# Altium schematic sheet SPU_circuit.SchDoc
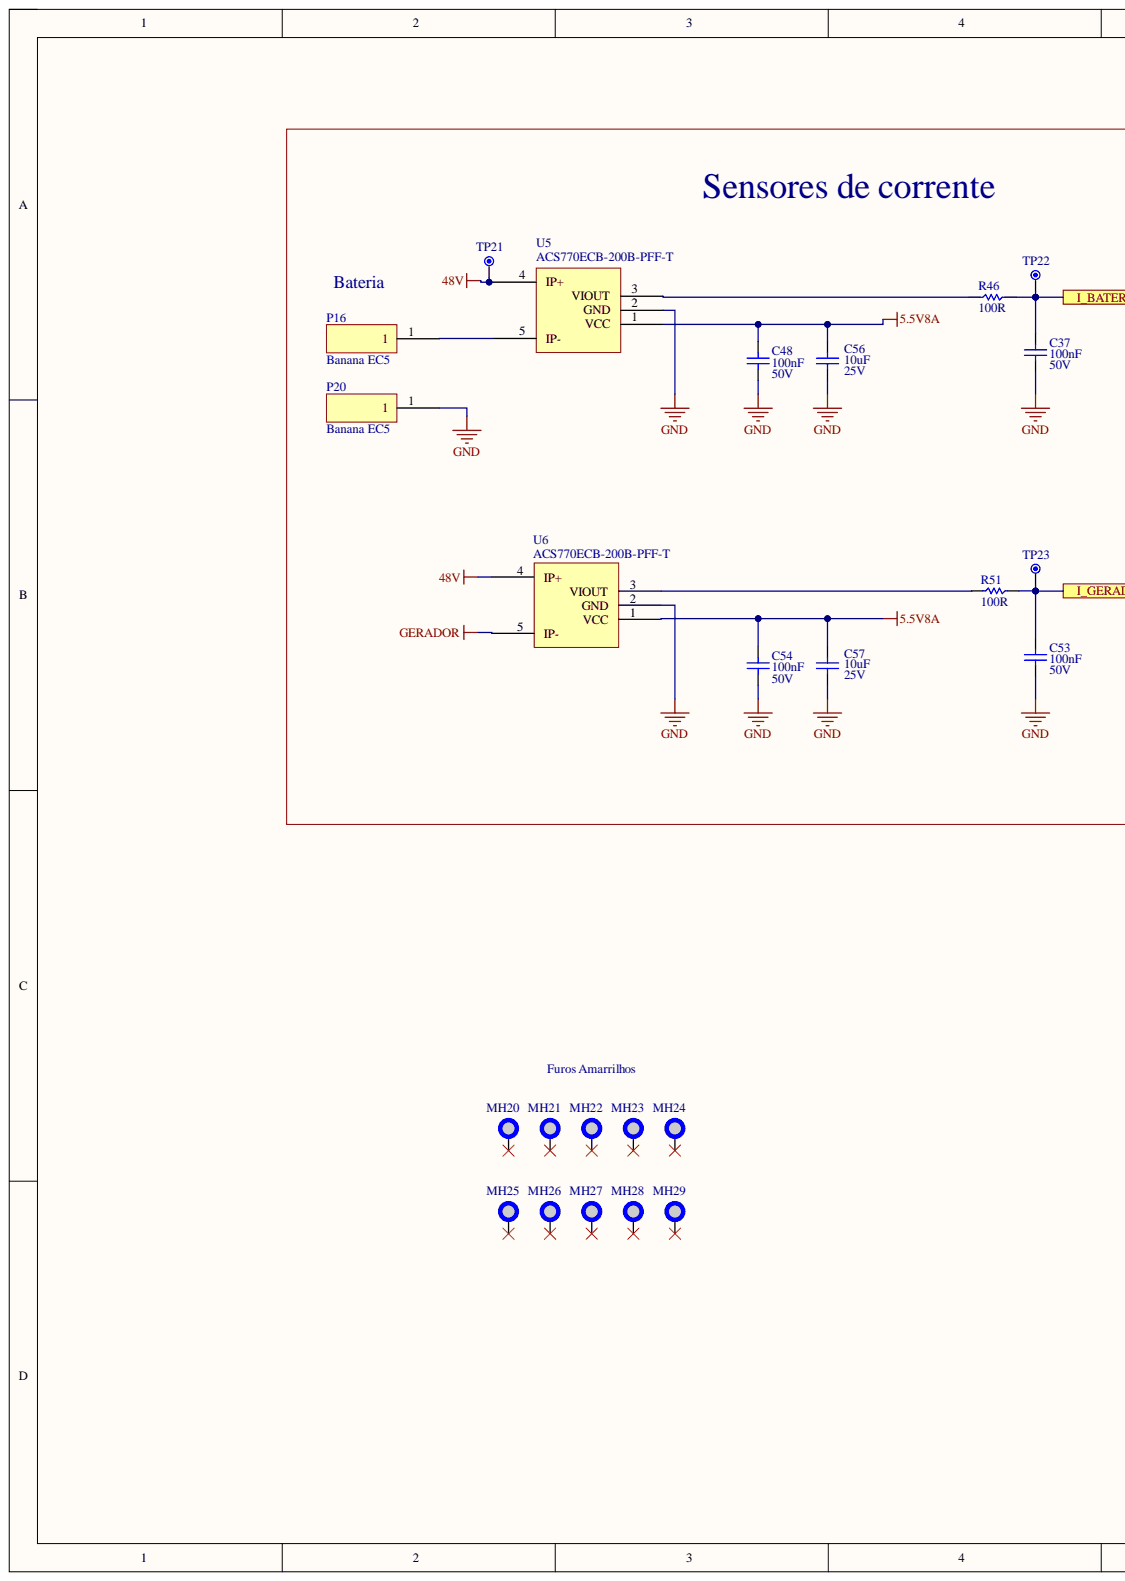
[diagram p0: SPU_circuit.SchDoc, left]
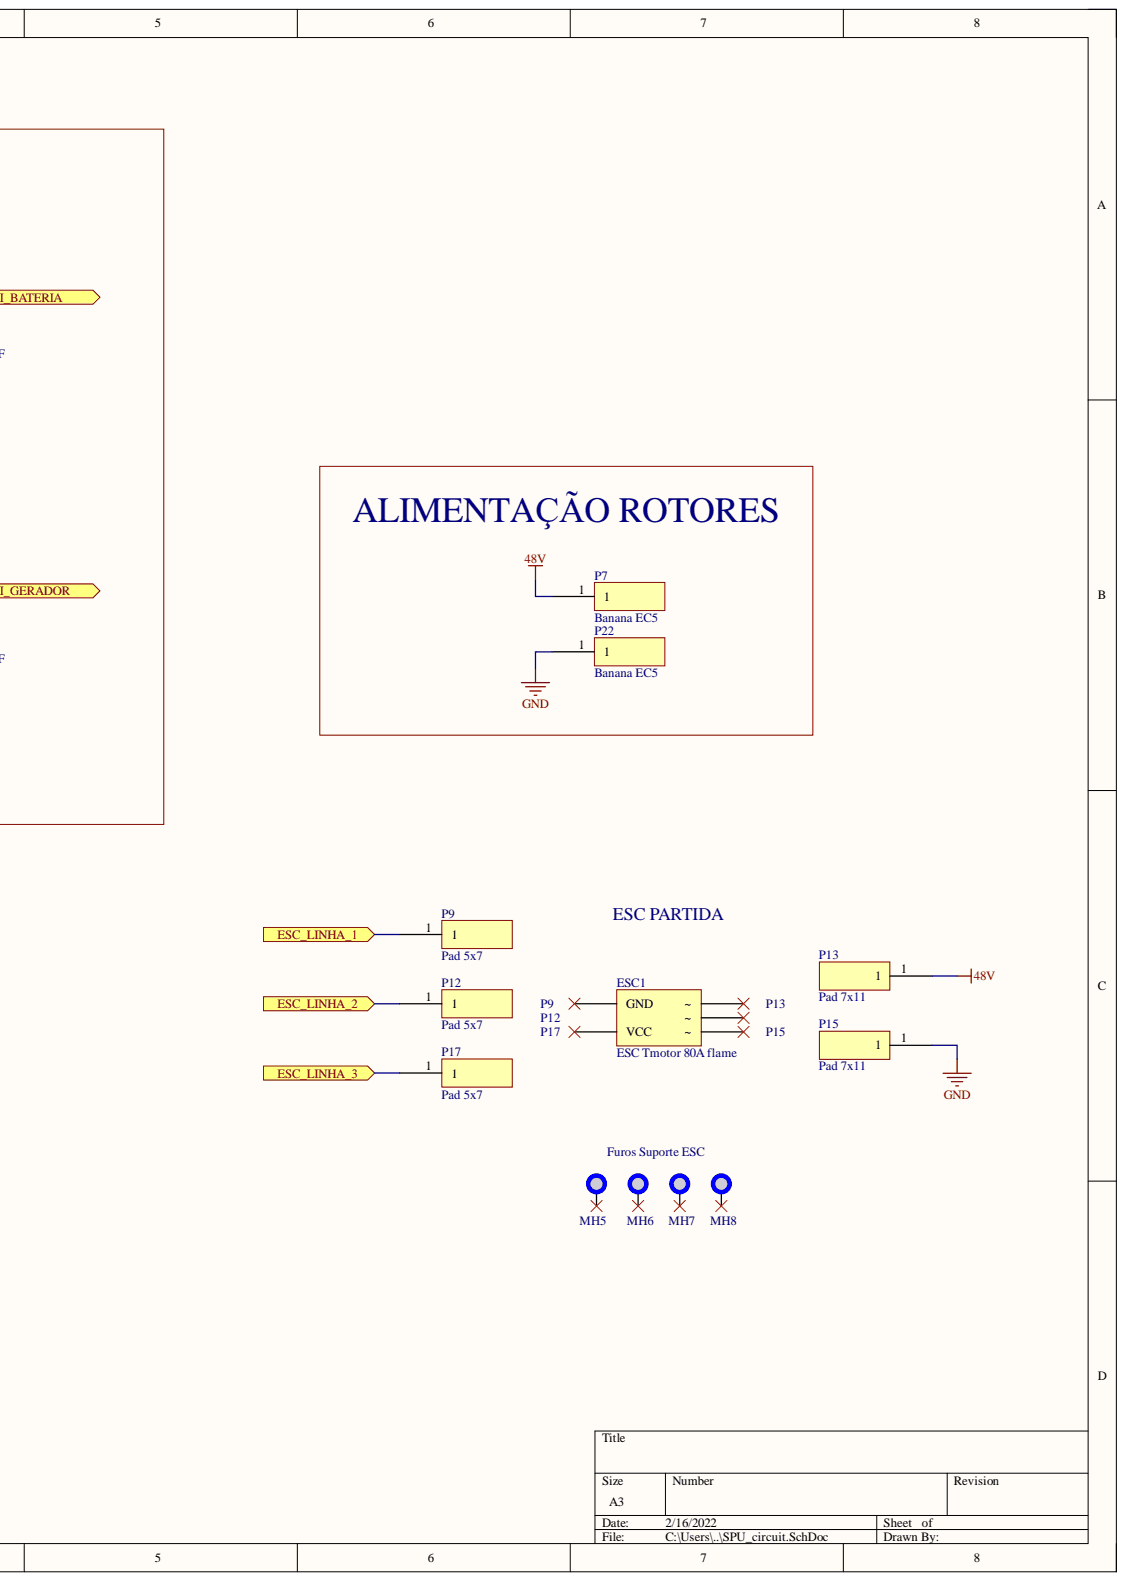
[diagram p1: SPU_circuit.SchDoc, right]

## Parts
C37  Capacitance: 100nF  Voltage: 50V  [SMD_0603]  (hidden comment: CL10B104MB8NNNC)
C48  Capacitance: 100nF  Voltage: 50V  [SMD_0603]  (hidden comment: CL10B104MB8NNNC)
C53  Capacitance: 100nF  Voltage: 50V  [SMD_0603]  (hidden comment: CL10B104MB8NNNC)
C54  Capacitance: 100nF  Voltage: 50V  [SMD_0603]  (hidden comment: CL10B104MB8NNNC)
C56  Capacitance: 10uF  Voltage: 25V  [1206]  (hidden comment: C3216X7R1E106K160AB)
C57  Capacitance: 10uF  Voltage: 25V  [1206]  (hidden comment: C3216X7R1E106K160AB)
ESC1  ESC Tmotor 80A flame  [ESC Tmotor 80Aflame - Unico]
MH5  TR-MOUNTING_HOLE-M2  [MOUNTING_HOLE-M3]  (value not drawn)
MH6  TR-MOUNTING_HOLE-M2  [MOUNTING_HOLE-M3]  (value not drawn)
MH7  TR-MOUNTING_HOLE-M2  [MOUNTING_HOLE-M3]  (value not drawn)
MH8  TR-MOUNTING_HOLE-M2  [MOUNTING_HOLE-M3]  (value not drawn)
MH20  TR-MOUNTING_HOLE-AMRARRILHA  [MOUNTING_HOLE-AMARRILHA]  (value not drawn)
MH21  TR-MOUNTING_HOLE-AMRARRILHA  [MOUNTING_HOLE-AMARRILHA]  (value not drawn)
MH22  TR-MOUNTING_HOLE-AMRARRILHA  [MOUNTING_HOLE-AMARRILHA]  (value not drawn)
MH23  TR-MOUNTING_HOLE-AMRARRILHA  [MOUNTING_HOLE-AMARRILHA]  (value not drawn)
MH24  TR-MOUNTING_HOLE-AMRARRILHA  [MOUNTING_HOLE-AMARRILHA]  (value not drawn)
MH25  TR-MOUNTING_HOLE-AMRARRILHA  [MOUNTING_HOLE-AMARRILHA]  (value not drawn)
MH26  TR-MOUNTING_HOLE-AMRARRILHA  [MOUNTING_HOLE-AMARRILHA]  (value not drawn)
MH27  TR-MOUNTING_HOLE-AMRARRILHA  [MOUNTING_HOLE-AMARRILHA]  (value not drawn)
MH28  TR-MOUNTING_HOLE-AMRARRILHA  [MOUNTING_HOLE-AMARRILHA]  (value not drawn)
MH29  TR-MOUNTING_HOLE-AMRARRILHA  [MOUNTING_HOLE-AMARRILHA]  (value not drawn)
P7  Banana EC5  [AWG8-MPU-VERTICAL]
P9  Pad 5x7  [FURO_7x5]
P12  Pad 5x7  [FURO_7x5]
P13  Pad 7x11  [FURO_11x7]
P15  Pad 7x11  [FURO_11x7]
P16  Banana EC5  [AWG8-MPU-VERTICAL]
P17  Pad 5x7  [FURO_7x5]
P20  Banana EC5  [AWG8-MPU-VERTICAL]
P22  Banana EC5  [AWG8-MPU-VERTICAL]
R46  Resistance: 100R  [SMD_0603]  (hidden comment: R-100R-0603)
R51  Resistance: 100R  [SMD_0603]  (hidden comment: R-100R-0603)
TP21  TP-1MM  [TESTPOINT-1MM]  (value not drawn)  (no BOM)
TP22  TP-1MM  [TESTPOINT-1MM]  (value not drawn)  (no BOM)
TP23  TP-1MM  [TESTPOINT-1MM]  (value not drawn)  (no BOM)
U5  ACS770ECB-200B-PFF-T  [CB-PFF-5_0]
U6  ACS770ECB-200B-PFF-T  [CB-PFF-5_0]

## Ports
ESC_LINHA_1, ESC_LINHA_2, ESC_LINHA_3, I_BATERIA, I_GERADOR

## Nets
5.5V8A: C48.2 C54.2 C56.2 C57.2 U5.1(VCC) U6.1(VCC)
48V: P7.1 P13.1 TP21.1 U5.4(IP+) U6.4(IP+)
GERADOR: U6.5(IP-)
GND: C37.1 C48.1 C53.1 C54.1 C56.1 C57.1 P15.1 P20.1 P22.1 U5.2(GND) U6.2(GND)
ESC_LINHA_1: P9.1 ; port ESC_LINHA_1
ESC_LINHA_2: P12.1 ; port ESC_LINHA_2
ESC_LINHA_3: P17.1 ; port ESC_LINHA_3
I_BATERIA: C37.2 R46.2 TP22.1 ; port I_BATERIA
I_GERADOR: C53.2 R51.2 TP23.1 ; port I_GERADOR
NetP16_1: P16.1 U5.5(IP-)
NetR46_1: R46.1 U5.3(VIOUT)
NetR51_1: R51.1 U6.3(VIOUT)

Not connected: ESC1 1(GND) 2(VCC) 3(~) 4(~) 5(~); MH5 1; MH6 1; MH7 1; MH8 1; MH20 1; MH21 1; MH22 1; MH23 1; MH24 1; MH25 1; MH26 1; MH27 1; MH28 1; MH29 1

## Library data of the parts
C37 C48 C53 C54 = C-CER-100nF-50V-X7R-0603: Capacitor 100nF 50V X7R 0603; Component Kind: Standard; Part Number: CL10B104MB8NNNC; Library Name: xmobots_-_comp_library.IntLib
C56 C57 = C-CER-10uF-25V-X7R-1206: Capacitor 10uF 25V X7R 1206; Component Kind: Standard; Part Number: C3216X7R1E106K160AB; Library Name: xmobots_-_comp_library.IntLib
P7 P9 P12 P13 P15-P17 P20 P22 = 1528-2: TERMINAL TURRET SINGLE .082" L; Component Kind: Standard; Supplier 1: Digi-Key; Supplier Part Number 1: 1528-2K-ND; Library Name: XM_Connector.SchLib
R46 R51 = R-100R-0603: Resistor 100R 0603; Component Kind: Standard; Library Name: WarLib.IntLib
U5 U6 = ACS758 LCB-100B-PFF-T: SENSOR CURRENT 100A 5V BI 5-CB; Component Kind: Standard; Supplier 1: Digi-Key; Supplier Part Number 1: 620-1321-ND; Library Name: XM_IC.SchLib
ESC1 = ESC Tmotor 80A flame: Component Kind: Standard; Library Name: XM_Silicon.SchLib
MH5-MH8 = TR-MOUNTING_HOLE-M2: Component Kind: Standard; Library Name: xmobots_-_comp_library.IntLib
MH20-MH29 = TR-MOUNTING_HOLE-AMRARRILHA: Component Kind: Standard; Library Name: xmobots_-_comp_library.IntLib
TP21-TP23 = TP-1MM: Library Name: xmobots_-_comp_library.IntLib
